# Altium schematic sheet Power.SchDoc
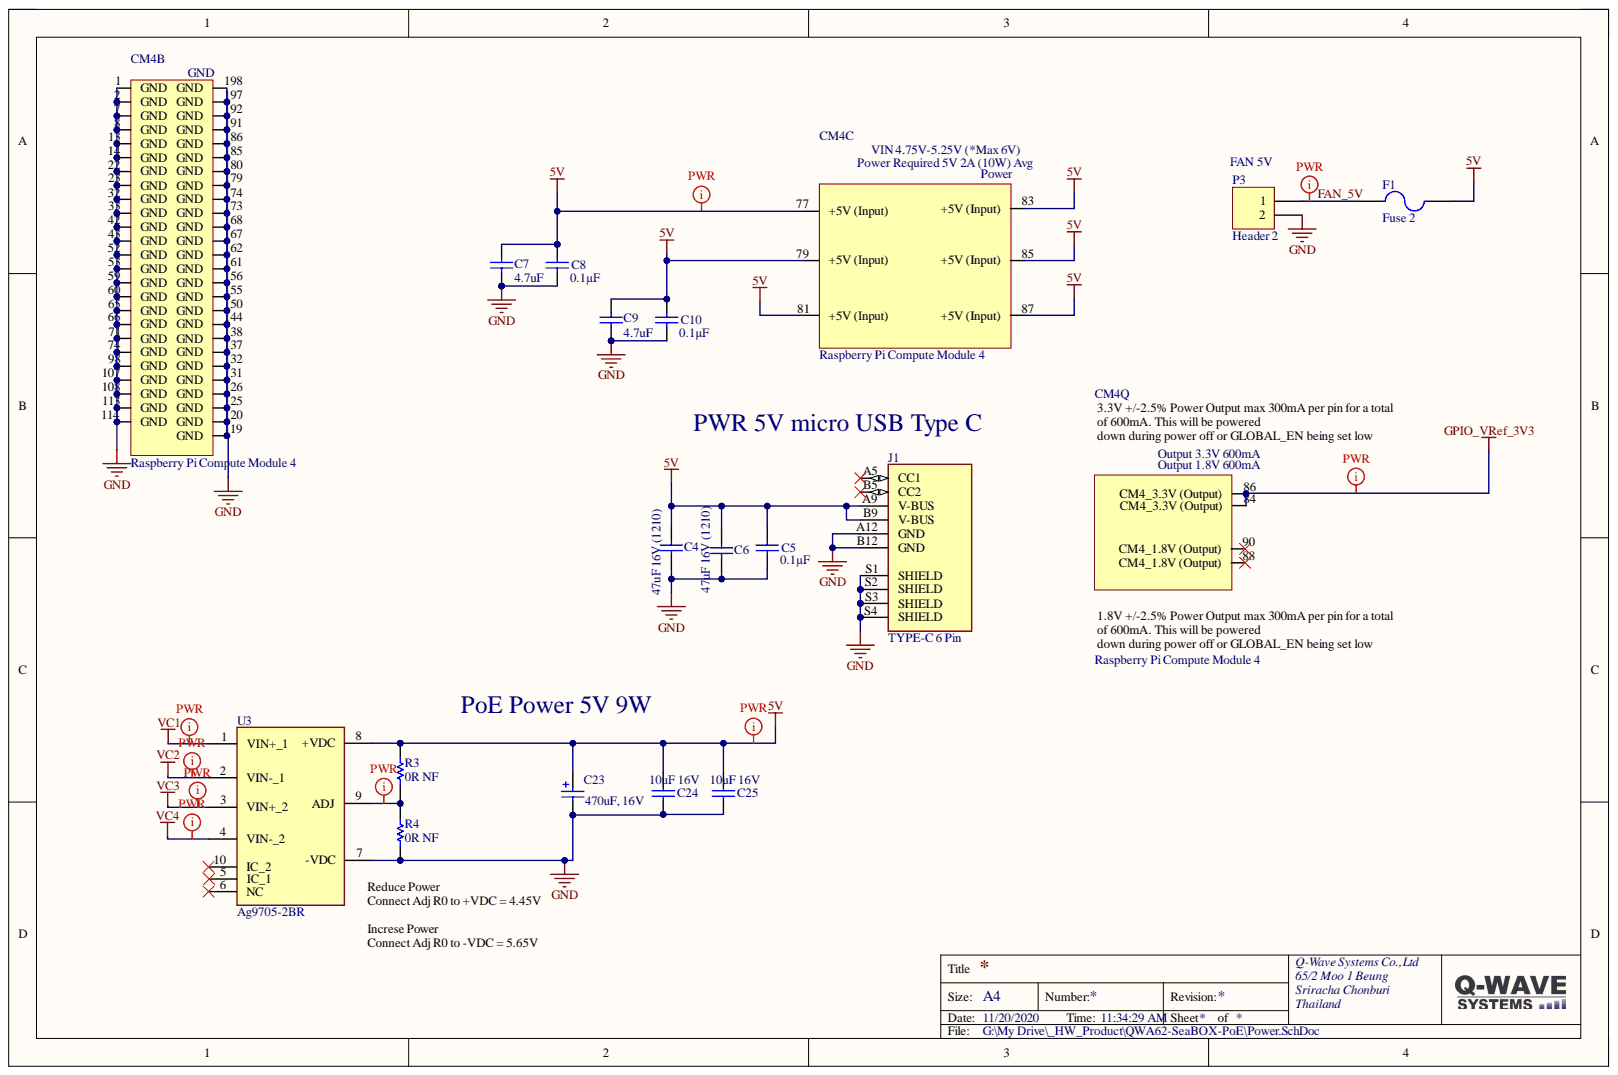
[diagram p0: Power.SchDoc]

## Parts
C4  47uF 16V (1210)  [C 1210]
C5  0.1µF  [CAP_0603]
C6  47uF 16V (1210)  [C 1210]
C7  4.7uF  [CAP_0805]
C8  0.1µF  [CAP_0603]
C9  4.7uF  [CAP_0805]
C10  0.1µF  [CAP_0603]
C23  470uF, 16V  [WCAP-PSHP_10x10.5mm]
C24  10uF 16V  [1210C-GENERIC]
C25  10uF 16V  [1210C-GENERIC]
CM4  Raspberry Pi Compute Module 4  [CM4-F40C-100DS-0.4v_1.5mm_0mm]  (parts B,C,Q)
F1  Fuse 2  [1206]
J1  TYPE-C 6 Pin  [TYPE-C-31-M-17]
P3  Header 2  [HDR1X2]
R3  0R NF  [RES_0603]
R4  0R NF  [RES_0603]
U3  Ag9705-2BR  [AG97052BR]

## Nets
5V: C4.1 C5.1 C6.1 C7.1 C8.1 C9.1 C10.1 C23.2 C24.2 C25.2 CM4.77(+5V_(Input)) CM4.79(+5V_(Input)) CM4.81(+5V_(Input)) CM4.83(+5V_(Input)) CM4.85(+5V_(Input)) CM4.87(+5V_(Input)) F1.2 J1.A9(V-BUS) J1.B9(V-BUS) R3.1 U3.8(+VDC)
GND: C4.2 C5.2 C6.2 C7.2 C8.2 C9.2 C10.2 C23.1 C24.1 C25.1 CM4.1(GND) CM4.2(GND) CM4.7(GND) CM4.8(GND) CM4.13(GND) CM4.14(GND) CM4.22(GND) CM4.23(GND) CM4.32(GND) CM4.33(GND) CM4.42(GND) CM4.43(GND) CM4.52(GND) CM4.53(GND) CM4.59(GND) CM4.60(GND) CM4.65(GND) CM4.66(GND) CM4.71(GND) CM4.74(GND) CM4.98(GND) CM4.107(GND) CM4.108(GND) CM4.113(GND) CM4.114(GND) CM4.119(GND) CM4.120(GND) CM4.125(GND) CM4.126(GND) CM4.131(GND) CM4.132(GND) CM4.137(GND) CM4.138(GND) CM4.144(GND) CM4.150(GND) CM4.155(GND) CM4.156(GND) CM4.161(GND) CM4.162(GND) CM4.167(GND) CM4.168(GND) CM4.173(GND) CM4.174(GND) CM4.179(GND) CM4.180(GND) CM4.185(GND) CM4.186(GND) CM4.191(GND) CM4.192(GND) CM4.197(GND) CM4.198(GND) J1.A12(GND) J1.B12(GND) J1.S1(SHIELD) J1.S2(SHIELD) J1.S3(SHIELD) J1.S4(SHIELD) P3.2 R4.2 U3.7(-VDC)
GPIO_VRef_3V3: CM4.84 CM4.86
VC1: U3.1(VIN+_1)
VC2: U3.2(VIN-_1)
VC3: U3.3(VIN+_2)
VC4: U3.4(VIN-_2)
FAN_5V: F1.1 P3.1
NetR3_2: R3.2 R4.1 U3.9(ADJ)

Not connected: CM4 88 90; J1 A5(CC1) B5(CC2); U3 5(IC_1) 6(NC) 10(IC_2)

## Library data of the parts
C4 C6 = Cap: 47uF 16V Ceramic Capacitor X5R 1210; MFG: KEMET; MFG P/N: C0603C475K8PACTU; Component Kind: Standard; Library Name: Miscellaneous Devices.IntLib; Signal Integrity: Cap; Simulation: CAP
C5 C8 C10 = Cap Semi: 0.1µF ±5% 50V Ceramic Capacitor X7R 0603; MFG: KEMET; MFG P/N: C0603C104J5RACTU; Component Kind: Standard; Library Name: Miscellaneous Devices.IntLib; Signal Integrity: Cap Semi; Simulation: CAP
C7 C9 = Cap: 4.7µF ±10% 16V Ceramic Capacitor X5R 0805; MFG: KEMET; MFG P/N: C0603C475K8PACTU; Component Kind: Standard; Library Name: Miscellaneous Devices.IntLib; Signal Integrity: Cap; Simulation: CAP
C23 = 875115357006: WCAP-PSHP Aluminum Polymer Capacitors, size 10x10.5mm, 470uF, +/-20%, 16VDC; Dissipation Factor: 10%; ESR: 11mOhm; Leakage Current: 752uA; Ripple Current: 5.1A; Technical Reference: PSEF105471M016DVCTEB000; Capacitance: 470uF; Capacitance Tolerance: +/-20%; Component Kind: Standard; ComponentLink1Description: Manufacturer; ComponentLink1URL: http://www.we-online.de; ComponentLink2Description: Datasheet; ComponentLink2URL: https://katalog.we-online.com/pbs/datasheet/875115357006.pdf; Diameter: 10mm; Height: 10.5mm; Library Name: 875115852001_Altium_WCAP-PSHP_rev19a.IntLib; Mounting Technology: SMD; Operating Temperature: -55 to +105degC; Packaging: Tape and Reel; Part Number: 875115357006; Published Date: August 2019; Rated Voltage: 16VDC; Size: 10x10.5mm; Publisher: Wurth Elektronik
C24 C25 = Cap: Capacitor 1210; Part Number: 0805F476M100NT; Value: 47uF; Component Kind: Standard; LatestRevisionDate: 17-Jul-2002; LatestRevisionNote: Re-released for DXP Platform.; Library Name: Miscellaneous Devices.IntLib; PackageDescription: Radial Cap, Thru-Hole; 2 Leads; 0.3 in Pin Spacing; PackageReference: RAD-0.3; Published: 8-Jun-2000; Signal Integrity: Cap; Simulation: CAP; Supplier: LCSC; Publisher: Altium Limited
CM4 = CM4: Component Kind: Standard; Description: Raspberry Pi Compute Module 4; Library Name: CM4.SchLib
F1 = Fuse 2: Fuse; Component Kind: Standard; LatestRevisionDate: 17-Jul-2002; LatestRevisionNote: Re-released for DXP Platform.; Library Name: Miscellaneous Devices.IntLib; PackageDescription: Thru-Hole; 2 Leads; PackageReference: PIN-W2/E2.8; Published: 8-Jun-2000; Simulation: FUSE; Publisher: Altium Limited
J1 = TYPE-C-31-M-17: Manufacturer Korean Hroparts Elec  Mfr.Part # TYPE-C-31-M-17  LCSC Part # C283540; MF: {'manufacturer': u'CUI Devices'}; MP: UJC-HP-3-SMT-TR; Availability: Unavailable; Component Kind: Standard; Library Name: SnapEDAsch.SchLib; Package: None; Price: None
P3 = Header 2: Header, 2-Pin; Component Kind: Standard; LatestRevisionDate: 17-Jul-2002; LatestRevisionNote: Re-released for DXP Platform.; Library Name: Miscellaneous Connectors.IntLib; Signal Integrity: Connector; Publisher: Altium Limited
R3 R4 = Res Semi: Resistor 0603; Component Kind: Standard; Library Name: Miscellaneous Devices.IntLib; Signal Integrity: Res Semi; Simulation: RES
U3 = AG9705-2BR: Ag9705-FL or Ag9705-2BR; Manufacturer_Name: Silvertel; Component Kind: Standard; Datasheet Link: https://www.silvertel.com/images/datasheets/Ag9700-Datasheet-low-cost-isolated-Power-over-Ethernet-PoE-module-solution.pdf; Height: 14mm; Library Name: SamacSys.SchLib; Manufacturer_Part_Number: AG9705-2BR
